# Altium schematic sheet [5]-Keyboard.SchDoc
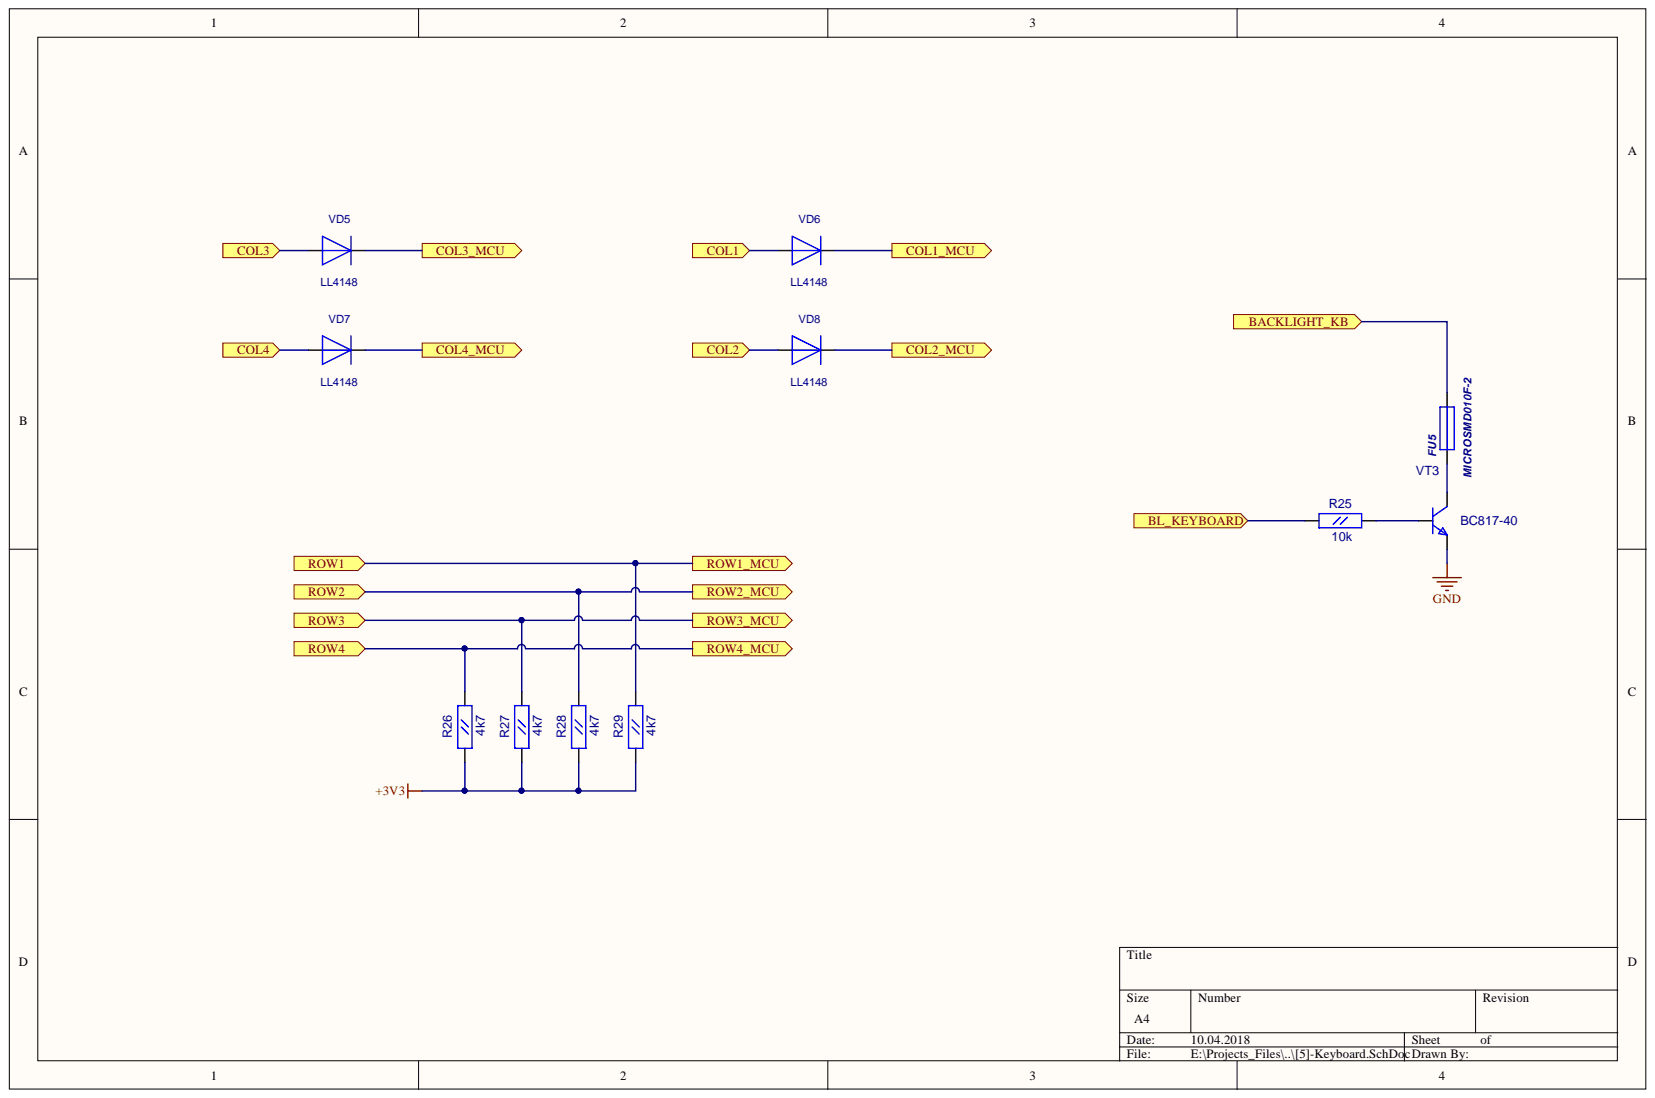
[diagram p0: [5]-Keyboard.SchDoc]

## Parts
FU5  MICROSMD010F-2  [RC1210]
R25  10k  [RC0603]
R26  4k7  [RC0603]
R27  4k7  [RC0603]
R28  4k7  [RC0603]
R29  4k7  [RC0603]
VD5  LL4148  [SOD-80]
VD6  LL4148  [SOD-80]
VD7  LL4148  [SOD-80]
VD8  LL4148  [SOD-80]
VT3  BC817-40  [SOT-23(123)]

## Ports
BACKLIGHT_KB, BL_KEYBOARD, COL1, COL1_MCU, COL2, COL2_MCU, COL3, COL3_MCU, COL4, COL4_MCU, ROW1, ROW1_MCU, ROW2, ROW2_MCU, ROW3, ROW3_MCU, ROW4, ROW4_MCU

## Nets
+3V3: R26.1 R27.1 R28.1 R29.1
GND: VT3.2
BACKLIGHT_KB: FU5.2 ; port BACKLIGHT_KB
BL_KEYBOARD: R25.1 ; port BL_KEYBOARD
COL1: VD6.1 ; port COL1
COL1_MCU: VD6.2 ; port COL1_MCU
COL2: VD8.1 ; port COL2
COL2_MCU: VD8.2 ; port COL2_MCU
COL3: VD5.1 ; port COL3
COL3_MCU: VD5.2 ; port COL3_MCU
COL4: VD7.1 ; port COL4
COL4_MCU: VD7.2 ; port COL4_MCU
ROW1: R29.2 ; port ROW1, port ROW1_MCU
ROW2: R28.2 ; port ROW2, port ROW2_MCU
ROW3: R27.2 ; port ROW3, port ROW3_MCU
ROW4: R26.2 ; port ROW4, port ROW4_MCU
NetFU5_1: FU5.1 VT3.3
NetR25_2: R25.2 VT3.1

## Library data of the parts
FU5 = MICROSMD: Component Kind: Standard; Description: MICROSMD; Value: MICROSMD010F-2; Library Name: gprs_modem_2.SchLib
R25-R29 = RC0603: Component Kind: Standard; Description: RC0603; Library Name: gprs_modem_2.SchLib
  R25: Value: 10k
  R26-R29: Value: 4k7
VT3 = BC846BLT1: NPN 500ma transistor; ComponentField7: Published 29-Sep-2000; Component Kind: Standard; OriginalName: BC846BLT1; Simulation: BC846BLT1; Value: BC817-40; Library Name: gprs_modem_2.SchLib
VD5-VD8 = LL4148: Component Kind: Standard; Description: LL4148; Library Name: gprs_modem_2.SchLib
